# Altium schematic sheet 016_TFCard.SchDoc(016_TFCard)
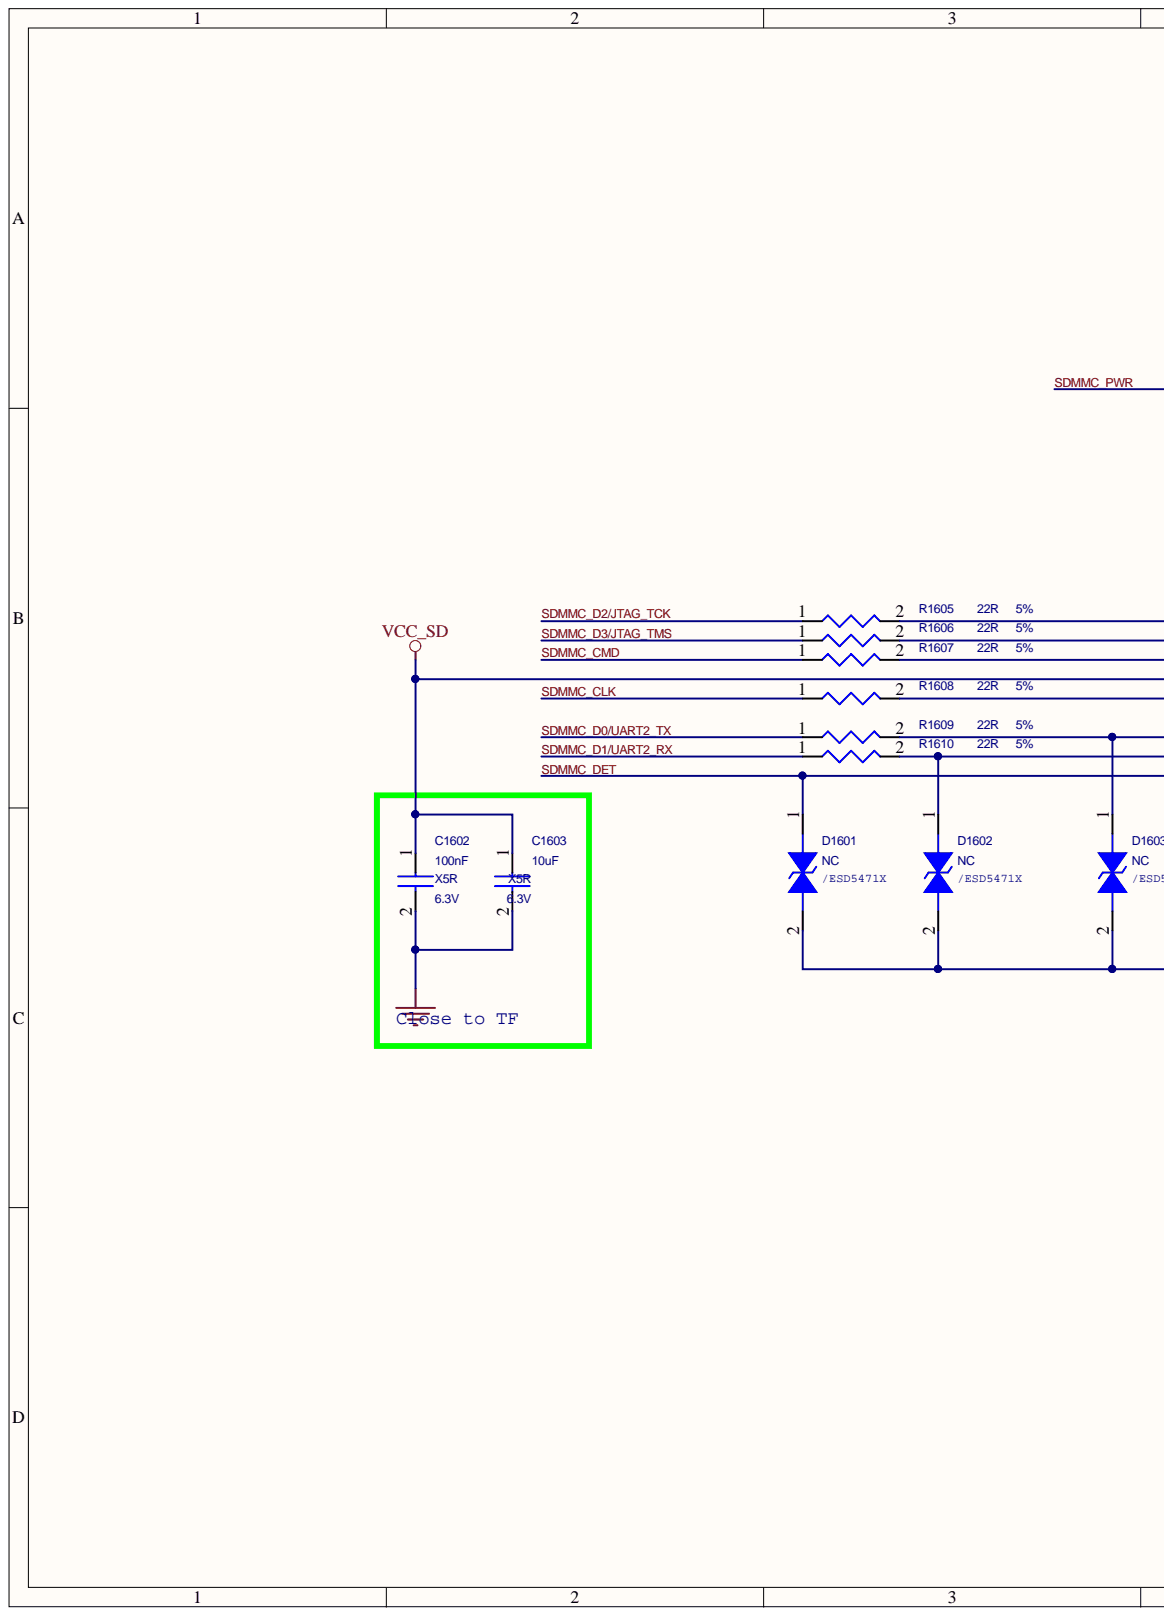
[diagram p0: 016_TFCard.SchDoc, left]
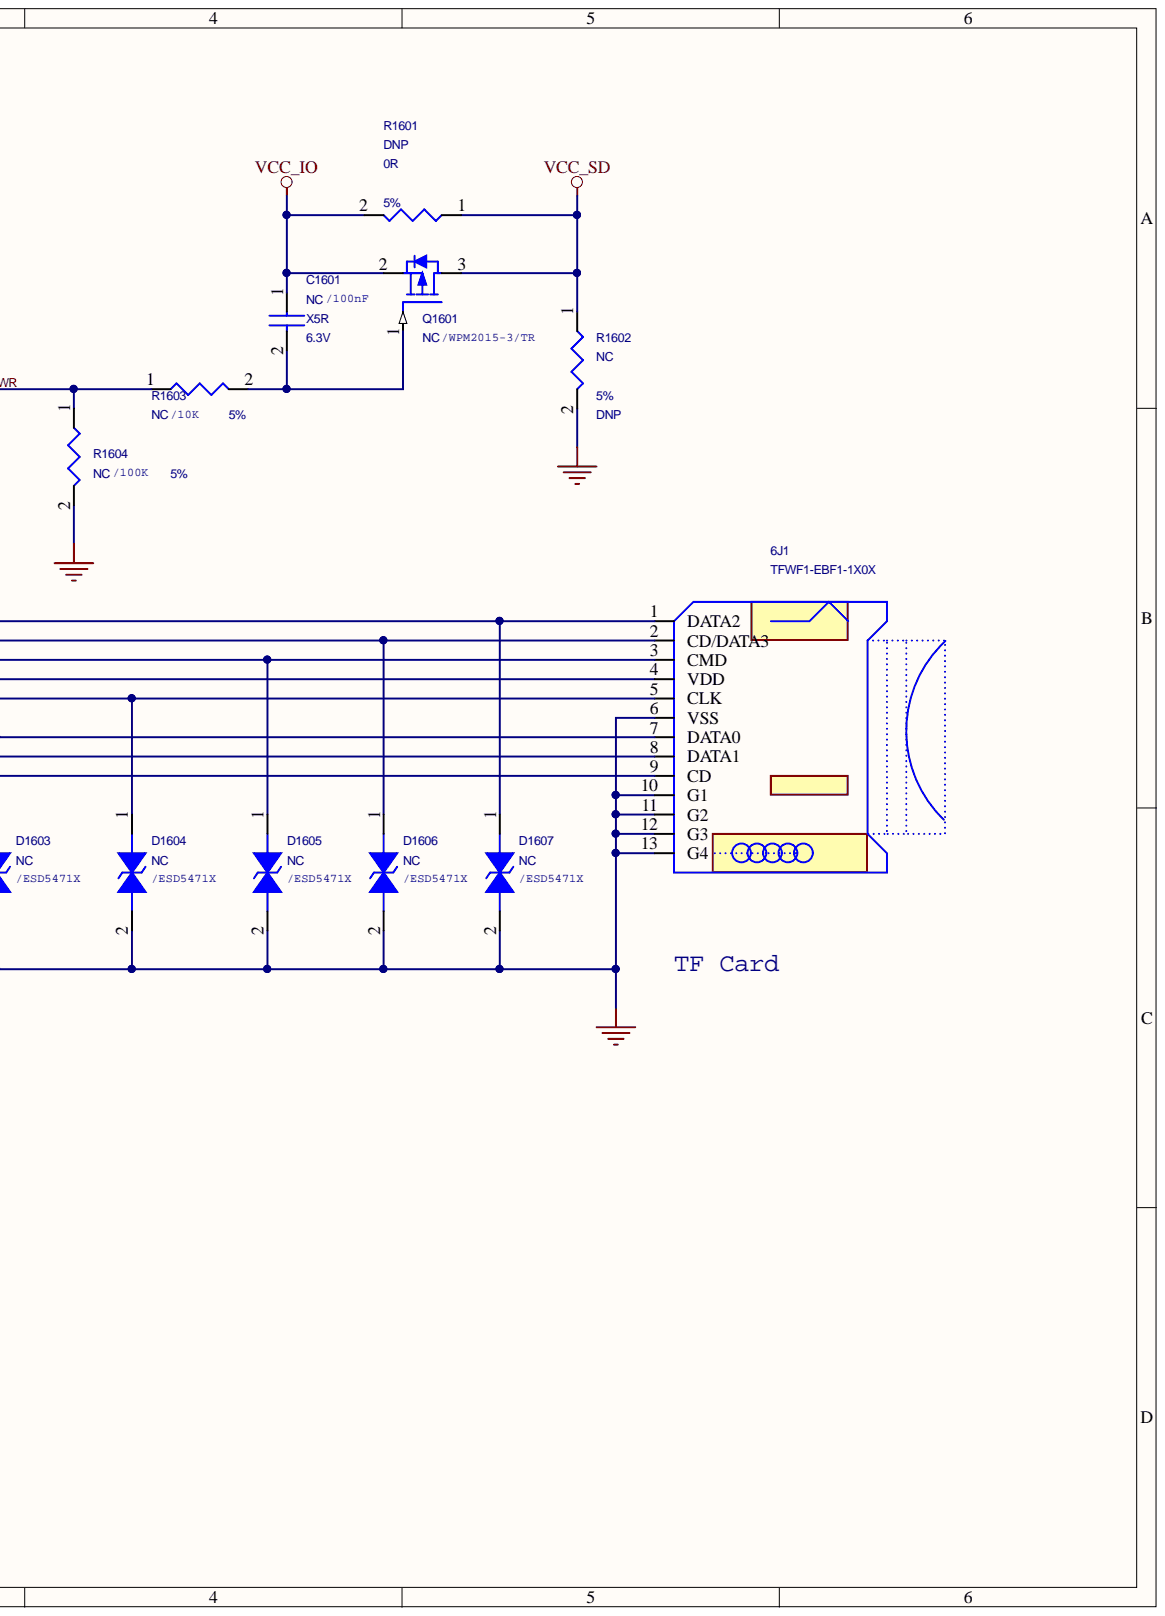
[diagram p1: 016_TFCard.SchDoc, right]

## Parts
6J1  TFWF1-EBF1-1X0X  [TF-CARD-PUSH]
C1601  NC  Voltage: 6.3V  Dielectric: X5R  [C0402]
C1602  100nF  Voltage: 6.3V  Dielectric: X5R  [C0402]
C1603  10uF  Voltage: 6.3V  Dielectric: X5R  [C0603]
D1601  NC  [ESD0402]
D1602  NC  [ESD0402]
D1603  NC  [ESD0402]
D1604  NC  [ESD0402]
D1605  NC  [ESD0402]
D1606  NC  [ESD0402]
D1607  NC  [ESD0402]
Q1601  NC  [SOT23]
R1601  0R  Tolerance: 5%  [R0402]
R1602  NC  Tolerance: 5%  option: DNP  [R0402]
R1603  NC  Tolerance: 5%  [R0402]
R1604  NC  [R0402]
R1605  22R  [R0402]
R1606  22R  [R0402]
R1607  22R  [R0402]
R1608  22R  [R0402]
R1609  22R  [R0402]
R1610  22R  [R0402]

## Nets
GND: 6J1.6(VSS) 6J1.10(G1) 6J1.11(G2) 6J1.12(G3) 6J1.13(G4) C1602.2 C1603.2 D1601.2 D1602.2 D1603.2 D1604.2 D1605.2 D1606.2 D1607.2 R1602.2 R1604.2
VCC_IO: C1601.1 Q1601.2 R1601.2
VCC_SD: 6J1.4(VDD) C1602.1 C1603.1 Q1601.3 R1601.1 R1602.1
SDMMC_CLK: R1608.1
SDMMC_CMD: R1607.1
SDMMC_D0/UART2_TX: R1609.1
SDMMC_D1/UART2_RX: R1610.1
SDMMC_D2/JTAG_TCK: R1605.1
SDMMC_D3/JTAG_TMS: R1606.1
SDMMC_DET: 6J1.9(CD) D1601.1
SDMMC_PWR: R1603.1 R1604.1
Net6J1_1: 6J1.1(DATA2) D1607.1 R1605.2
Net6J1_2: 6J1.2(CD/DATA3) D1606.1 R1606.2
Net6J1_3: 6J1.3(CMD) D1605.1 R1607.2
Net6J1_5: 6J1.5(CLK) D1604.1 R1608.2
Net6J1_7: 6J1.7(DATA0) D1603.1 R1609.2
Net6J1_8: 6J1.8(DATA1) D1602.1 R1610.2
NetC1601_2: C1601.2 Q1601.1 R1603.2

## Library data of the parts
6J1 = J-TF-CARD-PUSH: Component Kind: Standard
C1601 C1602 = CAP NP_Dup5: cap,100.00nF,+/-10%,6.3V,X5R,C0402; Manufacturer: TDK; Tolerance: 10%; RK PN: C1005X5R0J104K050BA; Priority: A; Number: 37; Part Type: C0402; Manufacturer PN: C1005X5R0J104K050BA; Component Kind: Standard; C_Voltage: 6.3V; Created by: JJJ
C1603 = CAP NP_Dup5: cap,10.00uF,+/-10%,6.3V,X5R,C0603; Manufacturer: TDK; Tolerance: 10%; RK PN: C1608X5R0J106K080AB; Priority: A; Part Type: C0603; Manufacturer PN: C1608X5R0J106K080AB; Component Kind: Standard; C_Voltage: 6.3V; Created by: JJJ
D1601-D1607 = DIODE BI_DIR TRIG: 1-Line, Bi-directional, Transient Voltage Suppressors; Manufacturer: WillSemi(甲먀군랄); RK PN: ESD5471X; Priority: A; Part Type: ESD_TVS; Manufacturer PN: ESD5471X; Component Kind: Standard; Created by: JJJ
Q1601 = MOSFET P_Dup1: PMOS,WPM2015-3/TR,SOT_23,Id=2.4A,Vds=20V; Manufacturer: WillSemi(甲먀군랄); Vgsth: 0.62V; Vgs: ˙8V; VDS: 20V; ROOM: CHG; Priority: A; Pd: 0.5W; Id_max: 2.4A; RK PN: WPM2015-3/TR; Part Type: PMOS; Manufacturer PN: WPM2015-3/TR; Component Kind: Standard; Created by: JJJ
R1601 = RESISTOR_Dup1: 哥棨슌훡롯量,0R,+/-5%,R0402,1/16W.; Manufacturer: YAGEO; Wattage: 1/16W; RK PN: RC0402JR-070RL; Priority: A; option: DNP; Part Type: 哥棨슌훡롯量; Manufacturer PN: RC0402JR-070RL; Component Kind: Standard; Created by: YTL
R1602 R1603 = RESISTOR_Dup1: 哥棨슌훡롯量,10K,+/-5%,R0402,1/16W.; Manufacturer: YAGEO; Wattage: 1/16W; RK PN: RC0402JR-0710KL; Priority: A; Part Type: 哥棨슌훡롯量; Manufacturer PN: RC0402JR-0710KL; Component Kind: Standard; Created by: YTL
R1604 = RESISTOR_Dup1: 哥棨슌훡롯量,100K,+/-5%,R0402,1/16W.; Manufacturer: YAGEO; Wattage: 1/16W; Tolerance: 5%; RK PN: RC0402JR-07100KL; Priority: A; Part Type: 哥棨슌훡롯量; Manufacturer PN: RC0402JR-07100KL; Component Kind: Standard; Created by: YTL
R1605-R1610 = RESISTOR_Dup1: 哥棨슌훡롯量,22R,+/-5%,R0402,1/16W.; Manufacturer: YAGEO; Wattage: 1/16W; Tolerance: 5%; RK PN: RC0402JR-0722RL; Priority: A; Part Type: 哥棨슌훡롯量; Manufacturer PN: RC0402JR-0722RL; Component Kind: Standard; Created by: YTL
